# Altium schematic sheet WL_IO002_V0.1_2.SchDoc
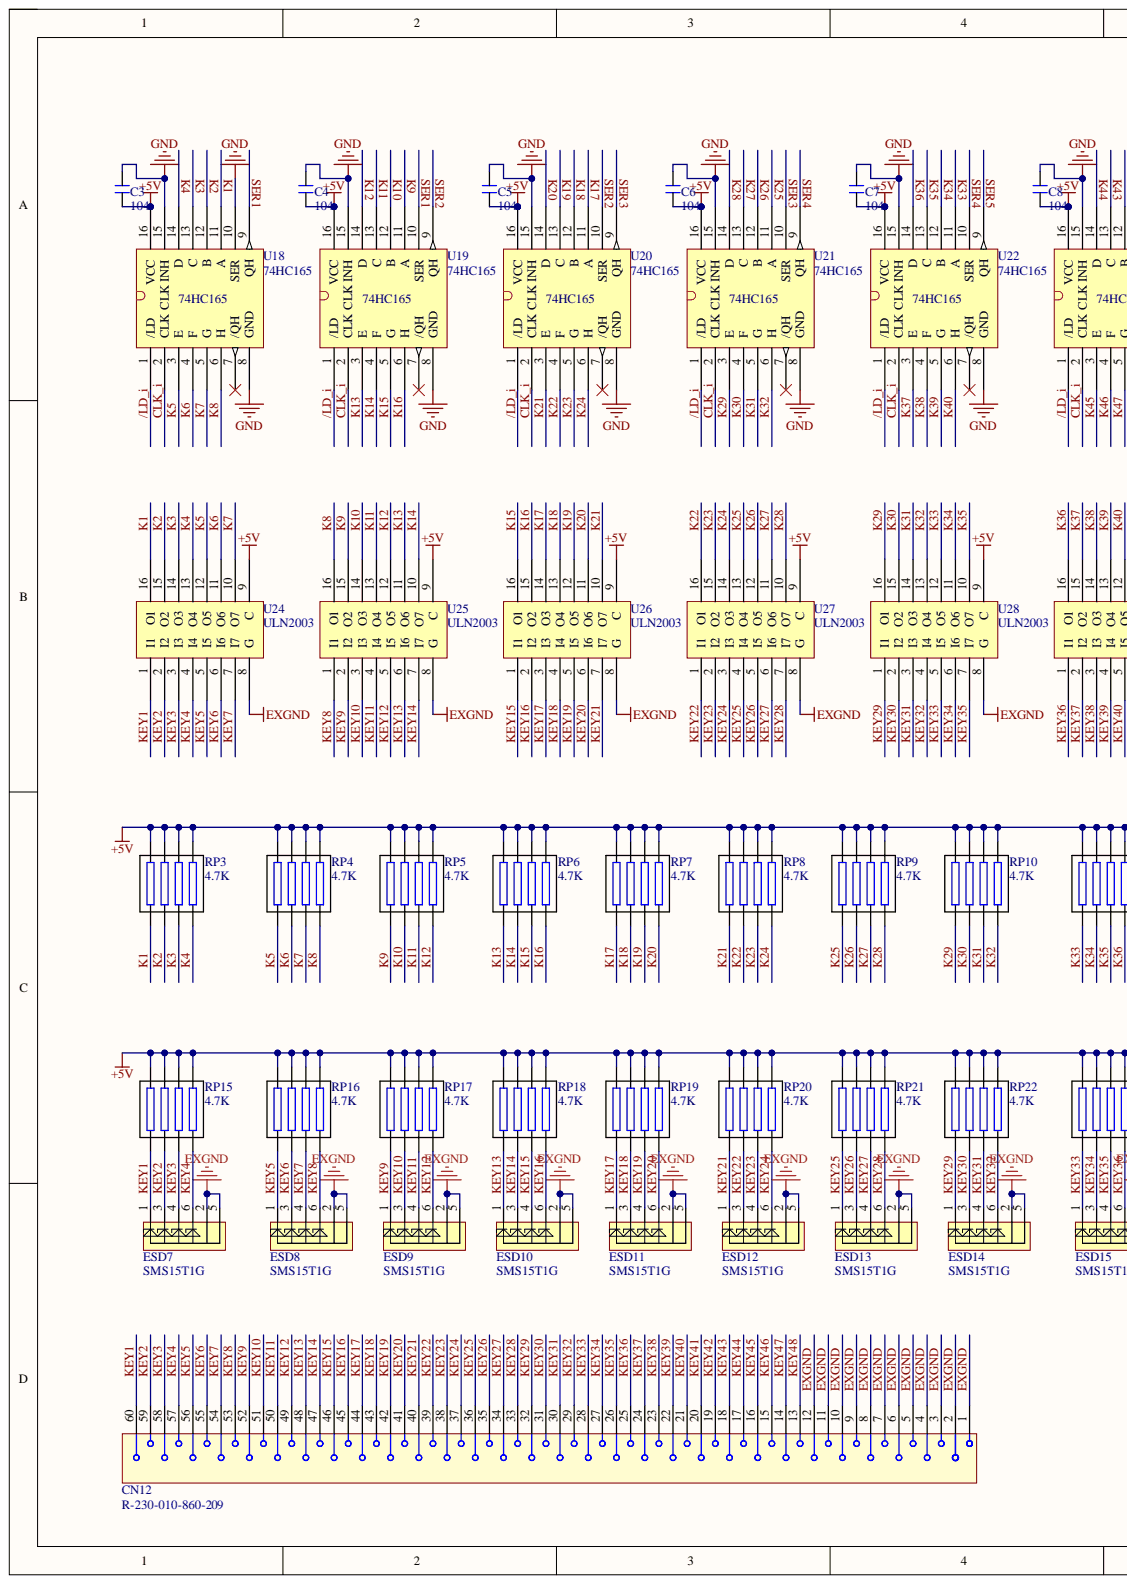
[diagram p0: WL_IO002_V0.1_2.SchDoc, left]
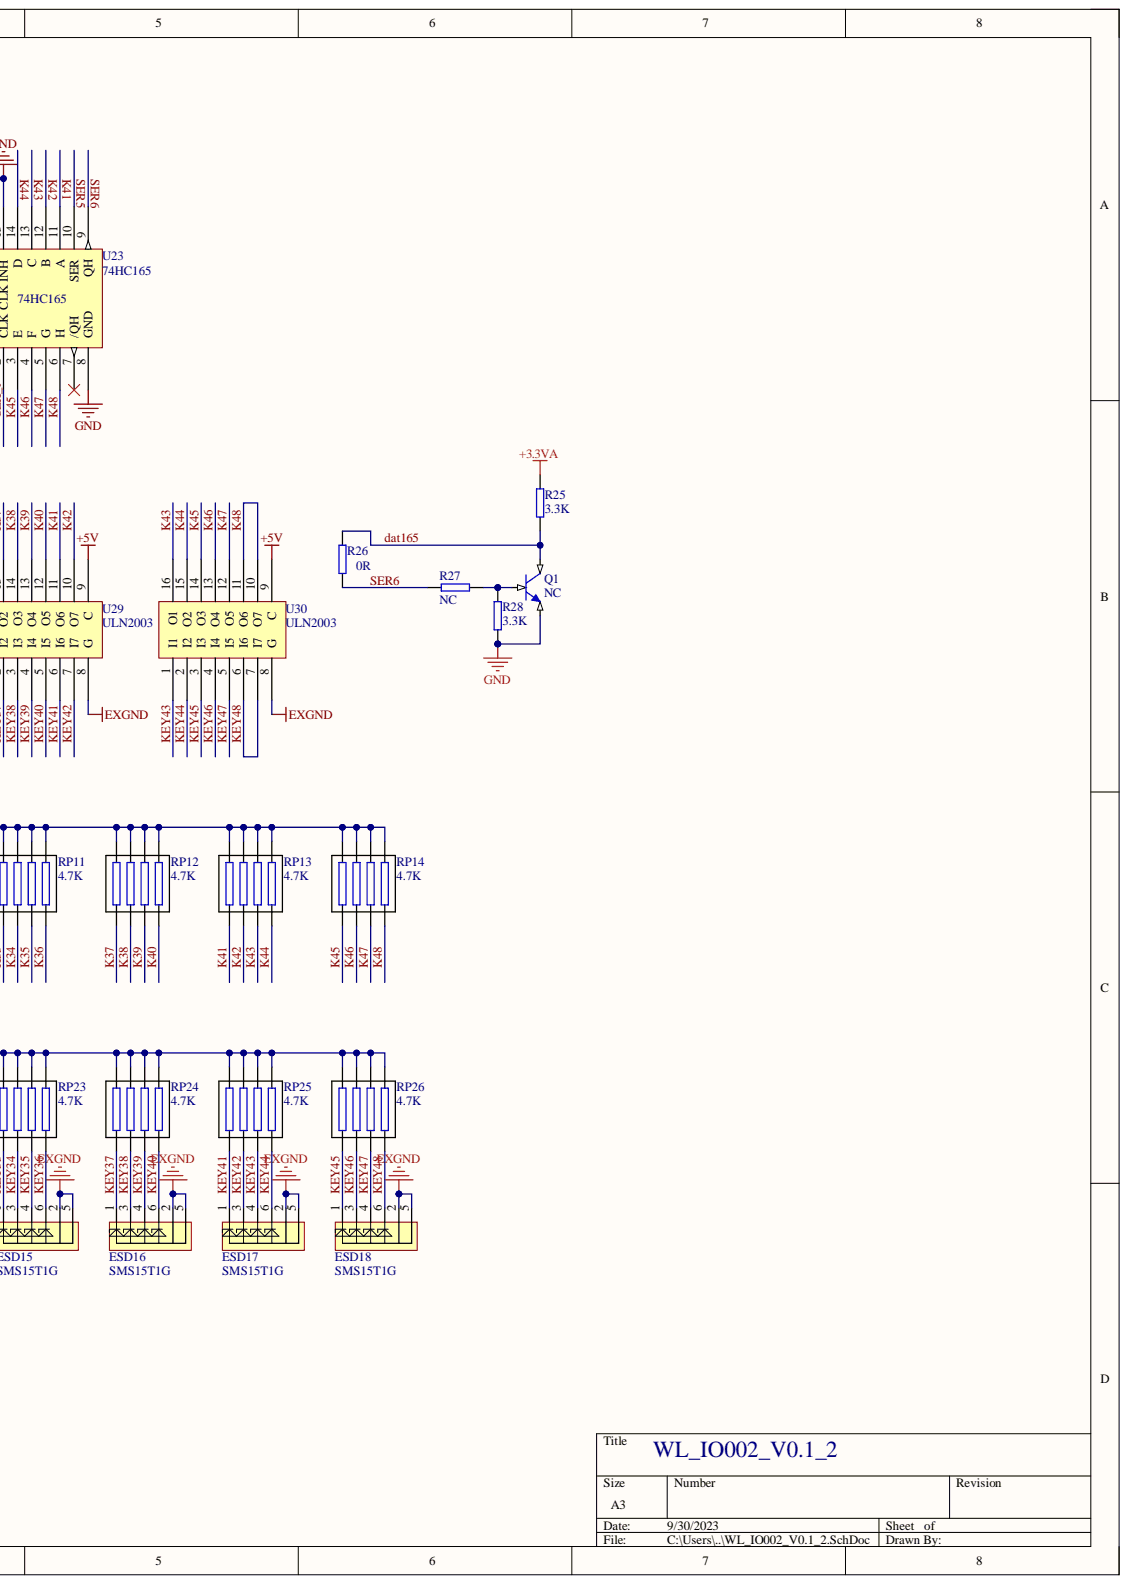
[diagram p1: WL_IO002_V0.1_2.SchDoc, right]

## Parts
C3  104  [C0805]
C4  104  [C0805]
C5  104  [C0805]
C6  104  [C0805]
C7  104  [C0805]
C8  104  [C0805]
CN12  R-230-010-860-209  [Z-2300(60PIN)]
ESD7  SMS15T1G  [SOT457/SC-74]
ESD8  SMS15T1G  [SOT457/SC-74]
ESD9  SMS15T1G  [SOT457/SC-74]
ESD10  SMS15T1G  [SOT457/SC-74]
ESD11  SMS15T1G  [SOT457/SC-74]
ESD12  SMS15T1G  [SOT457/SC-74]
ESD13  SMS15T1G  [SOT457/SC-74]
ESD14  SMS15T1G  [SOT457/SC-74]
ESD15  SMS15T1G  [SOT457/SC-74]
ESD16  SMS15T1G  [SOT457/SC-74]
ESD17  SMS15T1G  [SOT457/SC-74]
ESD18  SMS15T1G  [SOT457/SC-74]
Q1  NC  [SOT-23-3]
R25  3.3K  [0805]
R26  0R  [0805]
R27  NC  [0805]
R28  3.3K  [0805]
RP3  4.7K  [RCML08]
RP4  4.7K  [RCML08]
RP5  4.7K  [RCML08]
RP6  4.7K  [RCML08]
RP7  4.7K  [RCML08]
RP8  4.7K  [RCML08]
RP9  4.7K  [RCML08]
RP10  4.7K  [RCML08]
RP11  4.7K  [RCML08]
RP12  4.7K  [RCML08]
RP13  4.7K  [RCML08]
RP14  4.7K  [RCML08]
RP15  4.7K  [RCML08]
RP16  4.7K  [RCML08]
RP17  4.7K  [RCML08]
RP18  4.7K  [RCML08]
RP19  4.7K  [RCML08]
RP20  4.7K  [RCML08]
RP21  4.7K  [RCML08]
RP22  4.7K  [RCML08]
RP23  4.7K  [RCML08]
RP24  4.7K  [RCML08]
RP25  4.7K  [RCML08]
RP26  4.7K  [RCML08]
U18  74HC165  [SOP-3.8-16]
U19  74HC165  [SOP-3.8-16]
U20  74HC165  [SOP-3.8-16]
U21  74HC165  [SOP-3.8-16]
U22  74HC165  [SOP-3.8-16]
U23  74HC165  [SOP-3.8-16]
U24  ULN2003  [SOP-3.8-16]
U25  ULN2003  [SOP-3.8-16]
U26  ULN2003  [SOP-3.8-16]
U27  ULN2003  [SOP-3.8-16]
U28  ULN2003  [SOP-3.8-16]
U29  ULN2003  [SOP-3.8-16]
U30  ULN2003  [SOP-3.8-16]

## Nets
+3.3VA: R25.1
+5V: C3.1 C4.1 C5.1 C6.1 C7.1 C8.1 RP3.5 RP3.6 RP3.7 RP3.8 RP4.5 RP4.6 RP4.7 RP4.8 RP5.5 RP5.6 RP5.7 RP5.8 RP6.5 RP6.6 RP6.7 RP6.8 RP7.5 RP7.6 RP7.7 RP7.8 RP8.5 RP8.6 RP8.7 RP8.8 RP9.5 RP9.6 RP9.7 RP9.8 RP10.5 RP10.6 RP10.7 RP10.8 RP11.5 RP11.6 RP11.7 RP11.8 RP12.5 RP12.6 RP12.7 RP12.8 RP13.5 RP13.6 RP13.7 RP13.8 RP14.5 RP14.6 RP14.7 RP14.8 RP15.5 RP15.6 RP15.7 RP15.8 RP16.5 RP16.6 RP16.7 RP16.8 RP17.5 RP17.6 RP17.7 RP17.8 RP18.5 RP18.6 RP18.7 RP18.8 RP19.5 RP19.6 RP19.7 RP19.8 RP20.5 RP20.6 RP20.7 RP20.8 RP21.5 RP21.6 RP21.7 RP21.8 RP22.5 RP22.6 RP22.7 RP22.8 RP23.5 RP23.6 RP23.7 RP23.8 RP24.5 RP24.6 RP24.7 RP24.8 RP25.5 RP25.6 RP25.7 RP25.8 RP26.5 RP26.6 RP26.7 RP26.8 U18.16(VCC) U19.16(VCC) U20.16(VCC) U21.16(VCC) U22.16(VCC) U23.16(VCC) U24.9(C) U25.9(C) U26.9(C) U27.9(C) U28.9(C) U29.9(C) U30.9(C)
GND: C3.2 C4.2 C5.2 C6.2 C7.2 C8.2 Q1.2 R28.2 U18.8(GND) U18.10(SER) U18.15(CLK_INH) U19.8(GND) U19.15(CLK_INH) U20.8(GND) U20.15(CLK_INH) U21.8(GND) U21.15(CLK_INH) U22.8(GND) U22.15(CLK_INH) U23.8(GND) U23.15(CLK_INH)
/LD_i: U18.1(/LD) U19.1(/LD) U20.1(/LD) U21.1(/LD) U22.1(/LD) U23.1(/LD)
CLK_i: U18.2 U19.2 U20.2 U21.2 U22.2 U23.2
dat165: Q1.3 R25.2 R26.1
EXGND: CN12.1 CN12.2 CN12.3 CN12.4 CN12.5 CN12.6 CN12.7 CN12.8 CN12.9 CN12.10 CN12.11 CN12.12 ESD7.2 ESD7.5 ESD8.2 ESD8.5 ESD9.2 ESD9.5 ESD10.2 ESD10.5 ESD11.2 ESD11.5 ESD12.2 ESD12.5 ESD13.2 ESD13.5 ESD14.2 ESD14.5 ESD15.2 ESD15.5 ESD16.2 ESD16.5 ESD17.2 ESD17.5 ESD18.2 ESD18.5 U24.8(G) U25.8(G) U26.8(G) U27.8(G) U28.8(G) U29.8(G) U30.8(G)
K1: RP3.4 U18.11(A) U24.16(O1)
K2: RP3.3 U18.12(B) U24.15(O2)
K3: RP3.2 U18.13(C) U24.14(O3)
K4: RP3.1 U18.14(D) U24.13(O4)
K5: RP4.4 U18.3(E) U24.12(O5)
K6: RP4.3 U18.4(F) U24.11(O6)
K7: RP4.2 U18.5(G) U24.10(O7)
K8: RP4.1 U18.6(H) U25.16(O1)
K9: RP5.4 U19.11(A) U25.15(O2)
K10: RP5.3 U19.12(B) U25.14(O3)
K11: RP5.2 U19.13(C) U25.13(O4)
K12: RP5.1 U19.14(D) U25.12(O5)
K13: RP6.4 U19.3(E) U25.11(O6)
K14: RP6.3 U19.4(F) U25.10(O7)
K15: RP6.2 U19.5(G) U26.16(O1)
K16: RP6.1 U19.6(H) U26.15(O2)
K17: RP7.4 U20.11(A) U26.14(O3)
K18: RP7.3 U20.12(B) U26.13(O4)
K19: RP7.2 U20.13(C) U26.12(O5)
K20: RP7.1 U20.14(D) U26.11(O6)
K21: RP8.4 U20.3(E) U26.10(O7)
K22: RP8.3 U20.4(F) U27.16(O1)
K23: RP8.2 U20.5(G) U27.15(O2)
K24: RP8.1 U20.6(H) U27.14(O3)
K25: RP9.4 U21.11(A) U27.13(O4)
K26: RP9.3 U21.12(B) U27.12(O5)
K27: RP9.2 U21.13(C) U27.11(O6)
K28: RP9.1 U21.14(D) U27.10(O7)
K29: RP10.4 U21.3(E) U28.16(O1)
K30: RP10.3 U21.4(F) U28.15(O2)
K31: RP10.2 U21.5(G) U28.14(O3)
K32: RP10.1 U21.6(H) U28.13(O4)
K33: RP11.4 U22.11(A) U28.12(O5)
K34: RP11.3 U22.12(B) U28.11(O6)
K35: RP11.2 U22.13(C) U28.10(O7)
K36: RP11.1 U22.14(D) U29.16(O1)
K37: RP12.4 U22.3(E) U29.15(O2)
K38: RP12.3 U22.4(F) U29.14(O3)
K39: RP12.2 U22.5(G) U29.13(O4)
K40: RP12.1 U22.6(H) U29.12(O5)
K41: RP13.4 U23.11(A) U29.11(O6)
K42: RP13.3 U23.12(B) U29.10(O7)
K43: RP13.2 U23.13(C) U30.16(O1)
K44: RP13.1 U23.14(D) U30.15(O2)
K45: RP14.4 U23.3(E) U30.14(O3)
K46: RP14.3 U23.4(F) U30.13(O4)
K47: RP14.2 U23.5(G) U30.12(O5)
K48: RP14.1 U23.6(H) U30.10(O7) U30.11(O6)
KEY1: CN12.60 ESD7.1 RP15.4 U24.1(I1)
KEY2: CN12.59 ESD7.3 RP15.3 U24.2(I2)
KEY3: CN12.58 ESD7.4 RP15.2 U24.3(I3)
KEY4: CN12.57 ESD7.6 RP15.1 U24.4(I4)
KEY5: CN12.56 ESD8.1 RP16.4 U24.5(I5)
KEY6: CN12.55 ESD8.3 RP16.3 U24.6(I6)
KEY7: CN12.54 ESD8.4 RP16.2 U24.7(I7)
KEY8: CN12.53 ESD8.6 RP16.1 U25.1(I1)
KEY9: CN12.52 ESD9.1 RP17.4 U25.2(I2)
KEY10: CN12.51 ESD9.3 RP17.3 U25.3(I3)
KEY11: CN12.50 ESD9.4 RP17.2 U25.4(I4)
KEY12: CN12.49 ESD9.6 RP17.1 U25.5(I5)
KEY13: CN12.48 ESD10.1 RP18.4 U25.6(I6)
KEY14: CN12.47 ESD10.3 RP18.3 U25.7(I7)
KEY15: CN12.46 ESD10.4 RP18.2 U26.1(I1)
KEY16: CN12.45 ESD10.6 RP18.1 U26.2(I2)
KEY17: CN12.44 ESD11.1 RP19.4 U26.3(I3)
KEY18: CN12.43 ESD11.3 RP19.3 U26.4(I4)
KEY19: CN12.42 ESD11.4 RP19.2 U26.5(I5)
KEY20: CN12.41 ESD11.6 RP19.1 U26.6(I6)
KEY21: CN12.40 ESD12.1 RP20.4 U26.7(I7)
KEY22: CN12.39 ESD12.3 RP20.3 U27.1(I1)
KEY23: CN12.38 ESD12.4 RP20.2 U27.2(I2)
KEY24: CN12.37 ESD12.6 RP20.1 U27.3(I3)
KEY25: CN12.36 ESD13.1 RP21.4 U27.4(I4)
KEY26: CN12.35 ESD13.3 RP21.3 U27.5(I5)
KEY27: CN12.34 ESD13.4 RP21.2 U27.6(I6)
KEY28: CN12.33 ESD13.6 RP21.1 U27.7(I7)
KEY29: CN12.32 ESD14.1 RP22.4 U28.1(I1)
KEY30: CN12.31 ESD14.3 RP22.3 U28.2(I2)
KEY31: CN12.30 ESD14.4 RP22.2 U28.3(I3)
KEY32: CN12.29 ESD14.6 RP22.1 U28.4(I4)
KEY33: CN12.28 ESD15.1 RP23.4 U28.5(I5)
KEY34: CN12.27 ESD15.3 RP23.3 U28.6(I6)
KEY35: CN12.26 ESD15.4 RP23.2 U28.7(I7)
KEY36: CN12.25 ESD15.6 RP23.1 U29.1(I1)
KEY37: CN12.24 ESD16.1 RP24.4 U29.2(I2)
KEY38: CN12.23 ESD16.3 RP24.3 U29.3(I3)
KEY39: CN12.22 ESD16.4 RP24.2 U29.4(I4)
KEY40: CN12.21 ESD16.6 RP24.1 U29.5(I5)
KEY41: CN12.20 ESD17.1 RP25.4 U29.6(I6)
KEY42: CN12.19 ESD17.3 RP25.3 U29.7(I7)
KEY43: CN12.18 ESD17.4 RP25.2 U30.1(I1)
KEY44: CN12.17 ESD17.6 RP25.1 U30.2(I2)
KEY45: CN12.16 ESD18.1 RP26.4 U30.3(I3)
KEY46: CN12.15 ESD18.3 RP26.3 U30.4(I4)
KEY47: CN12.14 ESD18.4 RP26.2 U30.5(I5)
KEY48: CN12.13 ESD18.6 RP26.1 U30.6(I6) U30.7(I7)
SER1: U18.9(QH) U19.10(SER)
SER2: U19.9(QH) U20.10(SER)
SER3: U20.9(QH) U21.10(SER)
SER4: U21.9(QH) U22.10(SER)
SER5: U22.9(QH) U23.10(SER)
SER6: R26.2 R27.2 U23.9(QH)
NetQ1_1: Q1.1 R27.1 R28.1

Not connected: U18 7(/QH); U19 7(/QH); U20 7(/QH); U21 7(/QH); U22 7(/QH); U23 7(/QH)

## Library data of the parts
C3-C8 = CAP: 贴片陶瓷电容; Component Kind: Standard; Library Name: RCL.SchLib
CN12 = 60PIN: 牛角插座; Component Kind: Standard; Library Name: PIN.SchLib
ESD7-ESD18 = PESD12VS4UD: ESD保护; Component Kind: Standard; Library Name: D.SchLib
Q1 = NPN: Component Kind: Standard; Library Name: Q.SchLib
R25-R28 = RES: Resistor; Component Kind: Standard; Library Name: RCL.SchLib
RP3-RP26 = RCML08: 排阻; Component Kind: Standard; Library Name: RCL.SchLib
U18-U23 = 74HC165: parallel to serial; Component Kind: Standard; Library Name: IC.SchLib
U24-U30 = ULN2003: 达林顿二极管阵列; Component Kind: Standard; Library Name: IC.SchLib
